# Altium schematic sheet PANEL.SchDoc(PANEL)
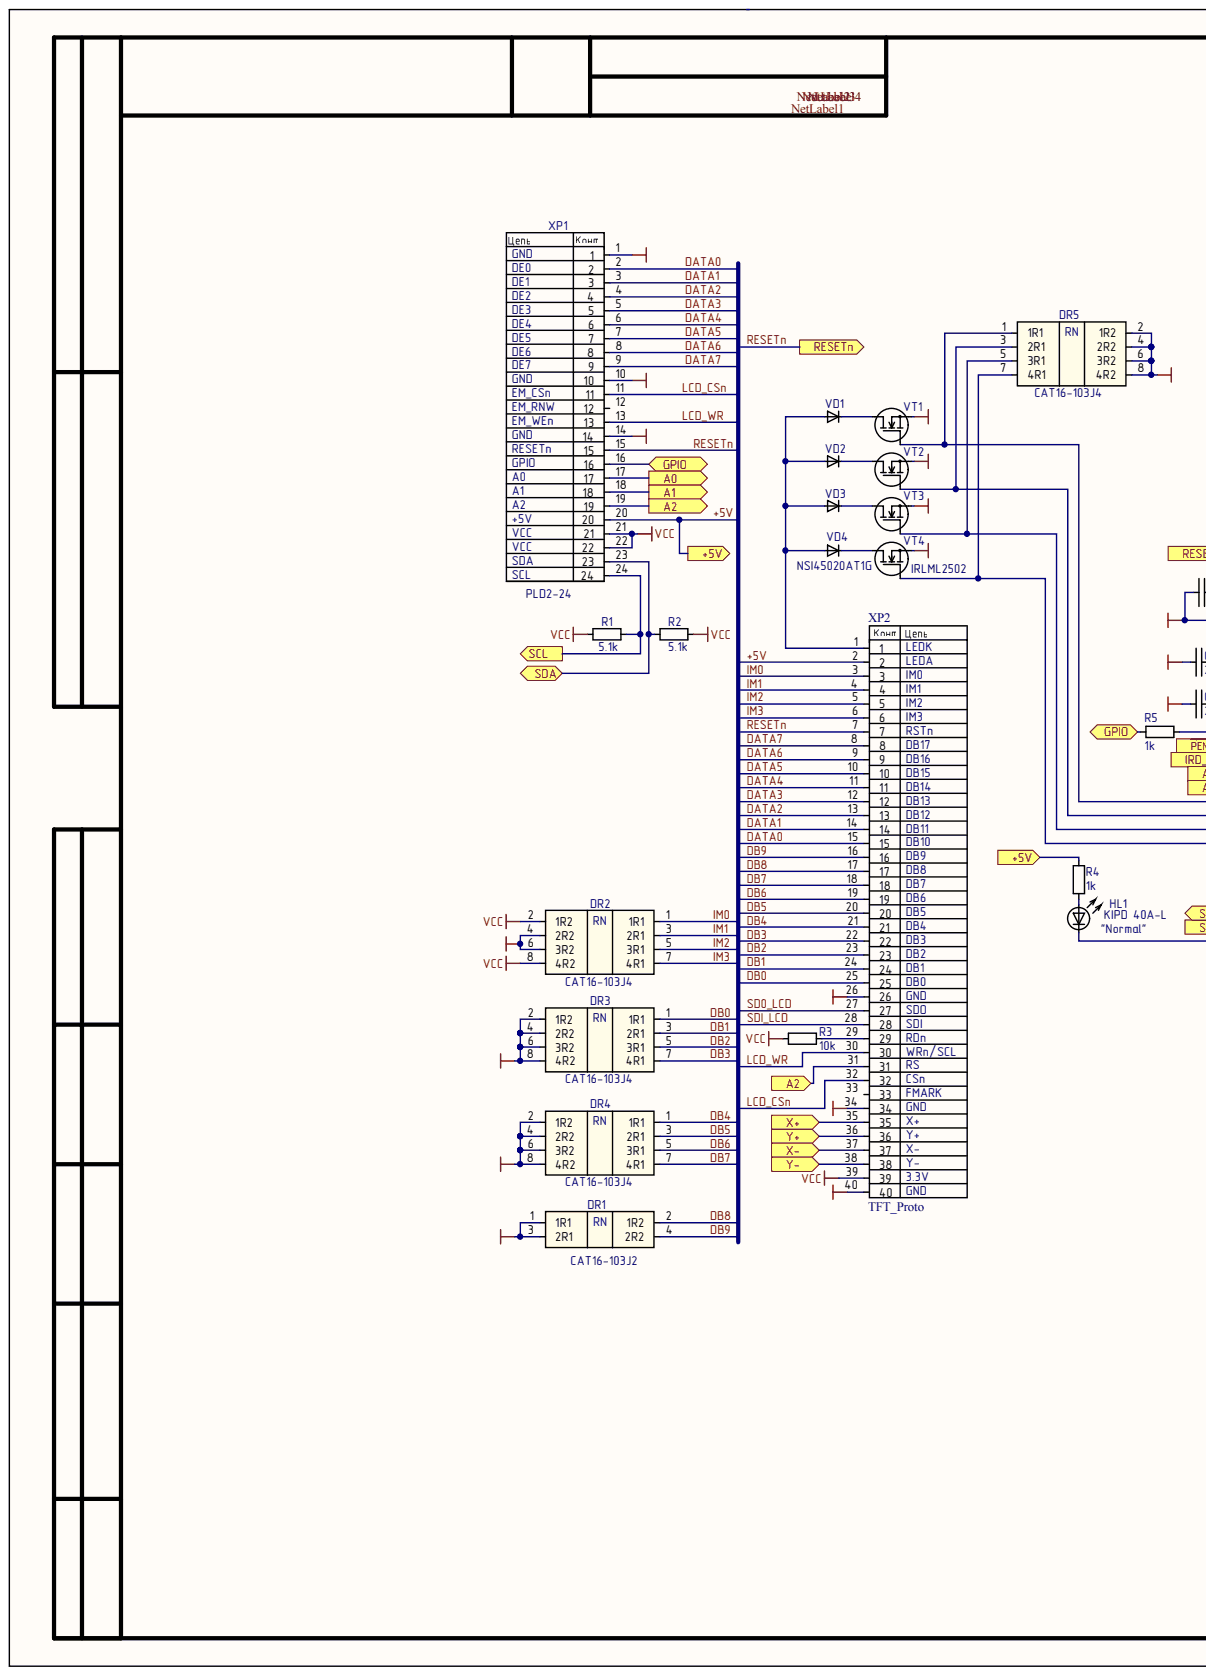
[diagram p0: PANEL.SchDoc, left]
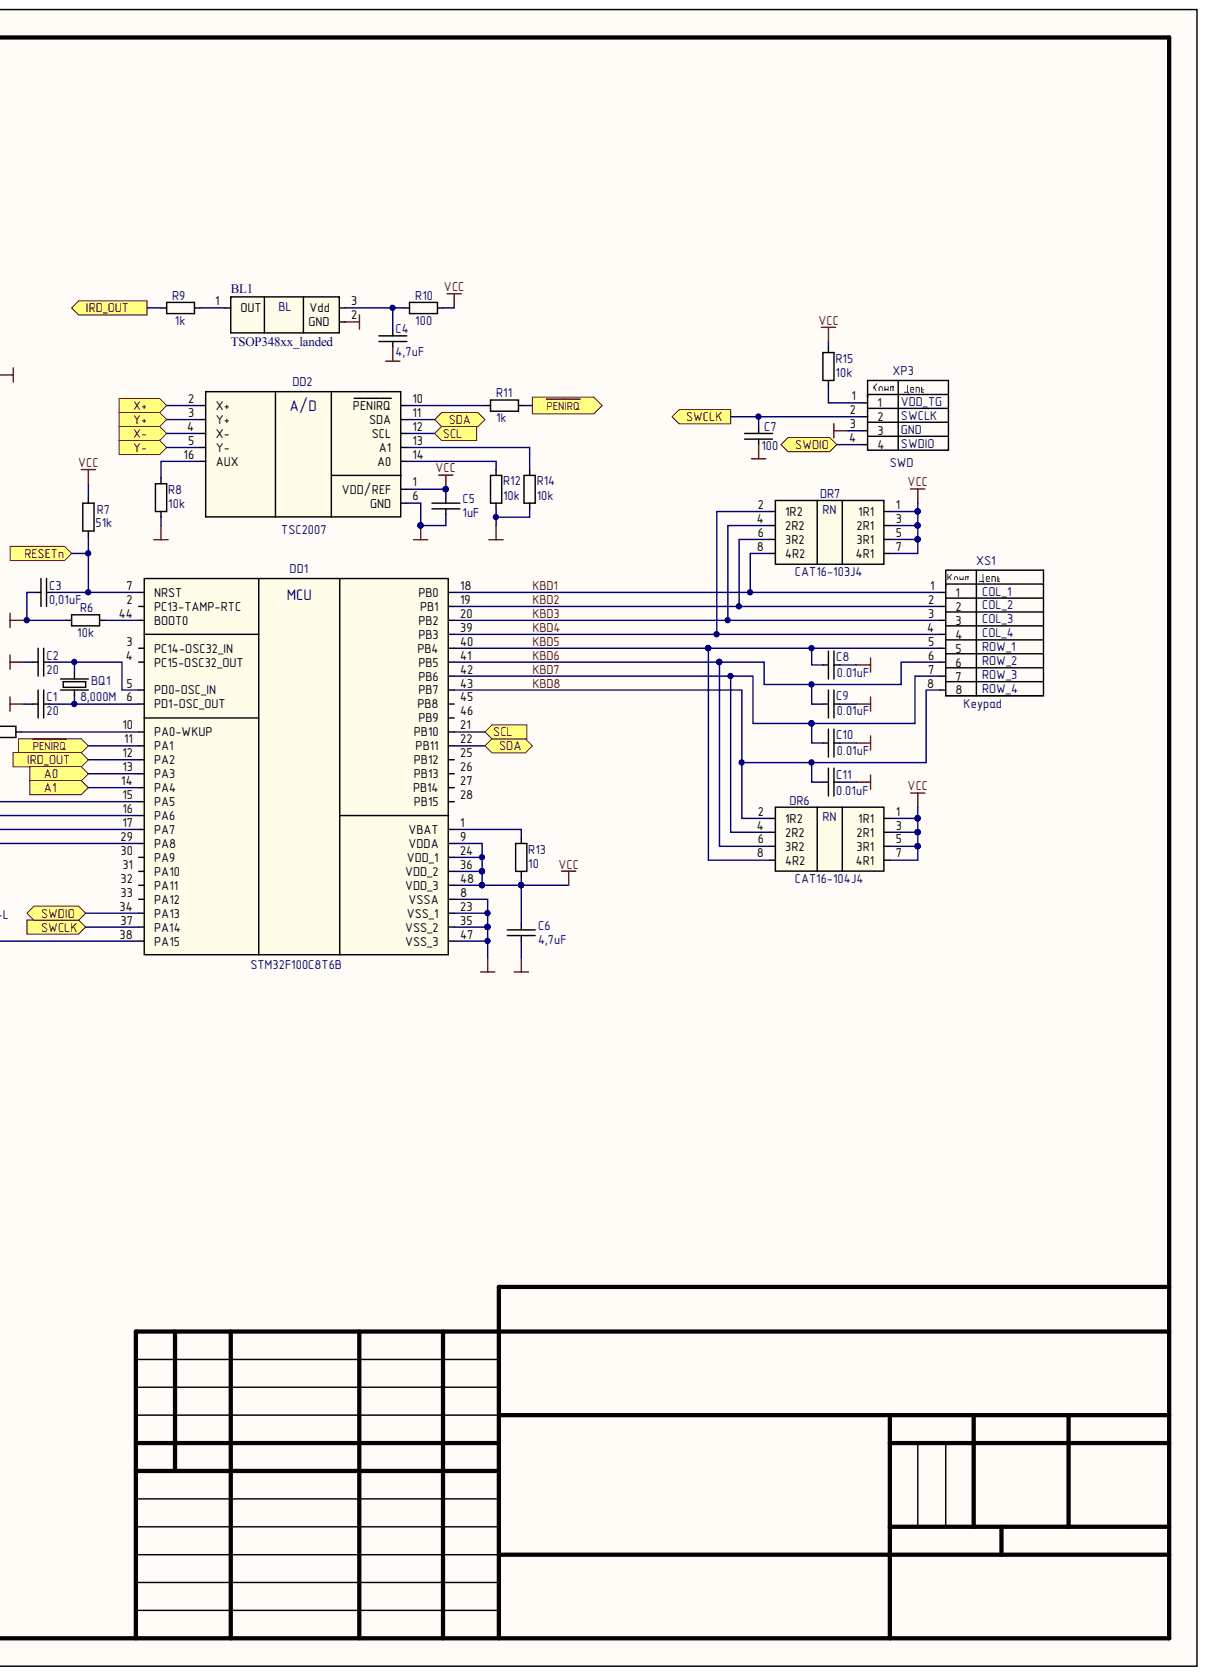
[diagram p1: PANEL.SchDoc, right]

## Parts
BL1  TSOP348xx_landed
BQ1  8,000M
C1  20
C2  20
C3  0,01uF
C4  4,7uF
C5  1uF
C6  4,7uF
C7  100
C8  0.01uF
C9  0.01uF
C10  0.01uF
C11  0.01uF
DD1  STM32F100C8T6B
DD2  TSC2007
DR1  CAT16-103J2
DR2  CAT16-103J4
DR3  CAT16-103J4
DR4  CAT16-103J4
DR5  CAT16-103J4
DR6  CAT16-104J4
DR7  CAT16-103J4
HL1  KIPD 40A-L
R1  5.1k
R2  5.1k
R3  10k
R4  1k
R5  1k
R6  10k
R7  51k
R8  10k
R9  1k
R10  100
R11  1k
R12  10k
R13  10
R14  10k
R15  10k
VD1  NSI45020AT1G
VD2  NSI45020AT1G
VD3  NSI45020AT1G
VD4  NSI45020AT1G
VT1  IRLML2502
VT2  IRLML2502
VT3  IRLML2502
VT4  IRLML2502
XP1  PLD2-24
XP2  TFT_Proto
XP3  SWD
XS1  Keypad

## Ports
+5V, A0, A1, A2, GPIO, IRD_OUT, RESETN, SCL, SDA, SWCLK, SWDIO, X+, X-, Y+, Y-, ~{PENIRQ}

## Nets
GND: BL1.2 C1.1 C2.1 C3.1 C4.1 C5.1 C6.1 C7.1 C8.1 C9.1 C10.1 C11.1 DD1.8(VSSA) DD1.23(VSS_1) DD1.35(VSS_2) DD1.47(VSS_3) DD2.6(GND) DR1.1(1R1) DR1.3(2R1) DR2.4(2R2) DR2.6(3R2) DR3.2(1R2) DR3.4(2R2) DR3.6(3R2) DR3.8(4R2) DR4.2(1R2) DR4.4(2R2) DR4.6(3R2) DR4.8(4R2) DR5.2(1R2) DR5.4(2R2) DR5.6(3R2) DR5.8(4R2) R6.2 R8.1 R12.1 R14.1 VT1.2 VT2.2 VT3.2 VT4.2 XP1.1 XP1.10 XP1.14 XP2.26 XP2.34 XP2.40 XP3.3
VCC: C5.2 C6.2 DD1.9(VDDA) DD1.24(VDD_1) DD1.36(VDD_2) DD1.48(VDD_3) DD2.1(VDD/REF) DR2.2(1R2) DR2.8(4R2) DR6.1(1R1) DR6.3(2R1) DR6.5(3R1) DR6.7(4R1) DR7.1(1R1) DR7.3(2R1) DR7.5(3R1) DR7.7(4R1) R1.2 R2.1 R3.2 R7.2 R10.2 R13.2 R15.2 XP1.21 XP1.22 XP2.39
+5V: R4.1 XP1.20 XP2.2 ; port +5V
A0: DD1.13(PA3) XP1.17 ; port A0
A1: DD1.14(PA4) XP1.18 ; port A1
A2: XP1.19 XP2.31 ; port A2
DATA0: XP1.2 XP2.15
DATA1: XP1.3 XP2.14
DATA2: XP1.4 XP2.13
DATA3: XP1.5 XP2.12
DATA4: XP1.6 XP2.11
DATA5: XP1.7 XP2.10
DATA6: XP1.8 XP2.9
DATA7: XP1.9 XP2.8
DB0: DR3.1(1R1) XP2.25
DB1: DR3.3(2R1) XP2.24
DB2: DR3.5(3R1) XP2.23
DB3: DR3.7(4R1) XP2.22
DB4: DR4.1(1R1) XP2.21
DB5: DR4.3(2R1) XP2.20
DB6: DR4.5(3R1) XP2.19
DB7: DR4.7(4R1) XP2.18
DB8: DR1.2(1R2) XP2.17
DB9: DR1.4(2R2) XP2.16
GPIO: R5.2 XP1.16 ; port GPIO
IM0: DR2.1(1R1) XP2.3
IM1: DR2.3(2R1) XP2.4
IM2: DR2.5(3R1) XP2.5
IM3: DR2.7(4R1) XP2.6
IRD_OUT: DD1.12(PA2) R9.1 ; port IRD_OUT
KBD1: DD1.18(PB0) DR7.8(4R2) XS1.1
KBD2: DD1.19(PB1) DR7.6(3R2) XS1.2
KBD3: DD1.20(PB2) DR7.4(2R2) XS1.3
KBD4: DD1.39(PB3) DR7.2(1R2) XS1.4
KBD5: C8.2 DD1.40(PB4) DR6.8(4R2) XS1.5
KBD6: C9.2 DD1.41(PB5) DR6.6(3R2) XS1.6
KBD7: C10.2 DD1.42(PB6) DR6.4(2R2) XS1.7
KBD8: C11.2 DD1.43(PB7) DR6.2(1R2) XS1.8
LCD_CSN: XP1.11 XP2.32
LCD_WR: XP1.13 XP2.30
~{PENIRQ}: DD1.11(PA1) R11.1 ; port ~{PENIRQ}
RESETN: C3.2 DD1.7(NRST) R7.1 XP1.15 XP2.7 ; port RESETN
SCL: DD1.21(PB10) DD2.12(SCL) R1.1 XP1.24 ; port SCL
SD0_LCD: XP2.27
SDA: DD1.22(PB11) DD2.11(SDA) R2.2 XP1.23 ; port SDA
SDI_LCD: XP2.28
SWCLK: C7.2 DD1.37(PA14) XP3.2 ; port SWCLK
SWDIO: DD1.34(PA13) XP3.4 ; port SWDIO
NetBL1_1: BL1.1 R9.2
NetBL1_3: BL1.3 C4.2 R10.1
NetBQ1_1: BQ1.1 C1.2 DD1.6(PD1-OSC_OUT)
NetBQ1_2: BQ1.2 C2.2 DD1.5(PD0-OSC_IN)
NetDD1_1: DD1.1(VBAT) R13.1
NetDD1_10: DD1.10(PA0-WKUP) R5.1
NetDD1_15: DD1.15(PA5) DR5.1(1R1) VT1.1
NetDD1_16: DD1.16(PA6) DR5.3(2R1) VT2.1
NetDD1_17: DD1.17(PA7) DR5.5(3R1) VT3.1
NetDD1_29: DD1.29(PA8) DR5.7(4R1) VT4.1
NetDD1_38: DD1.38(PA15) HL1.2
NetDD1_44: DD1.44(BOOT0) R6.1
NetDD2_2: DD2.2(X+) XP2.35
NetDD2_3: DD2.3(Y+) XP2.36
NetDD2_4: DD2.4(X-) XP2.37
NetDD2_5: DD2.5(Y-) XP2.38
NetDD2_10: DD2.10(PENIRQ) R11.2
NetDD2_13: DD2.13(A1) R14.2
NetDD2_14: DD2.14(A0) R12.2
NetDD2_16: DD2.16(AUX) R8.2
NetHL1_1: HL1.1 R4.2
NetR3_1: R3.1 XP2.29
NetR15_1: R15.1 XP3.1
NetVD1_1: VD1.1 VT1.3
NetVD1_2: VD1.2 VD2.2 VD3.2 VD4.2 XP2.1
NetVD2_1: VD2.1 VT2.3
NetVD3_1: VD3.1 VT3.3
NetVD4_1: VD4.1 VT4.3
N00088:
NETLABEL1:
NETLABEL2:
NETLABEL3:
NETLABEL4:

Not connected: DD1 2(PC13-TAMP-RTC) 3(PC14-OSC32_IN) 4(PC15-OSC32_OUT) 25(PB12) 26(PB13) 27(PB14) 28(PB15) 30(PA9) 31(PA10) 32(PA11) 33(PA12) 45(PB8) 46(PB9); XP1 12; XP2 33
